# Altium schematic sheet solenoid_drv.SchDoc
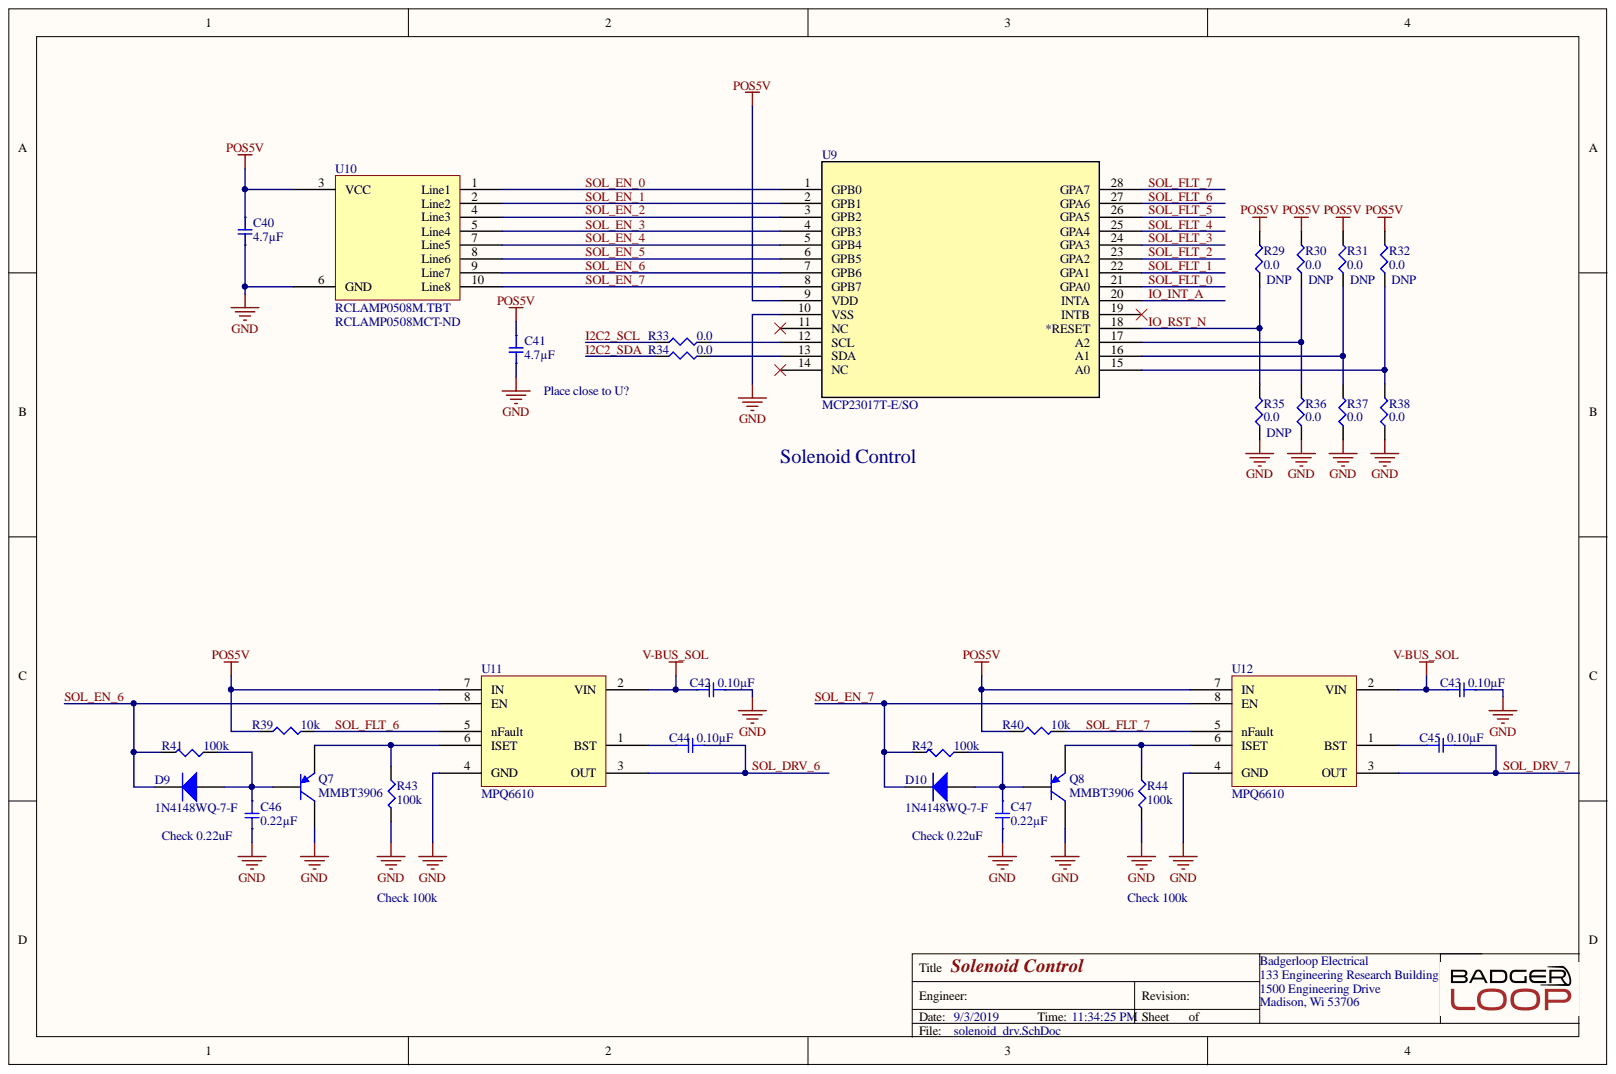
[diagram p0: solenoid_drv.SchDoc]

## Parts
C40  4.7µF  [SMD-0805C]
C41  4.7µF  [SMD-0805C]
C42  0.10µF  [SMD-0805C]
C43  0.10µF  [SMD-0805C]
C44  0.10µF  [SMD-0805C]
C45  0.10µF  [SMD-0805C]
C46  0.22µF  [SMD-0805C]
C47  0.22µF  [SMD-0805C]
D9  1N4148WQ-7-F  [INDM3838X18N]
D10  1N4148WQ-7-F  [INDM3838X18N]
Q7  MMBT3906  [SOTFL103P240X110-3N]
Q8  MMBT3906  [SOTFL103P240X110-3N]
R29  0.0  [SMD-0805-RES]
R30  0.0  [SMD-0805-RES]
R31  0.0  [SMD-0805-RES]
R32  0.0  [SMD-0805-RES]
R33  0.0  [SMD-0805-RES]
R34  0.0  [SMD-0805-RES]
R35  0.0  [SMD-0805-RES]
R36  0.0  [SMD-0805-RES]
R37  0.0  [SMD-0805-RES]
R38  0.0  [SMD-0805-RES]
R39  10k  [SMD-0805-RES]
R40  10k  [SMD-0805-RES]
R41  100k  [SMD-0805-RES]
R42  100k  [SMD-0805-RES]
R43  100k  [SMD-0805-RES]
R44  100k  [SMD-0805-RES]
U9  MCP23017T-E/SO  [SOIC28-W_MC-M]
U10  RCLAMP0508M.TBT  Supplier Part Number 1: RCLAMP0508MCT-ND  [SSOP50P490X175-10N]
U11  MPQ6610  [SOP127P600X175-8N]
U12  MPQ6610  [SOP127P600X175-8N]

## Nets
GND: C40.2 C41.2 C42.2 C43.2 C46.2 C47.2 Q7.3 Q8.3 R35.1 R36.1 R37.1 R38.1 R43.2 R44.2 U9.10(VSS) U10.6(GND) U11.4(GND) U12.4(GND)
POS5V: C40.1 C41.1 R29.2 R30.2 R31.2 R32.2 R39.1 R40.1 U9.9(VDD) U10.3(VCC) U11.7(IN) U12.7(IN)
V-BUS_SOL: C42.1 C43.1 U11.2(VIN) U12.2(VIN)
I2C2_SCL: R33.1
I2C2_SDA: R34.1
IO_INT_A: U9.20(INTA)
IO_RST_N: R29.1 R35.2 U9.18(*RESET)
SOL_DRV_6: C44.2 U11.3(OUT)
SOL_DRV_7: C45.2 U12.3(OUT)
SOL_EN_0: U9.1(GPB0) U10.1(Line1)
SOL_EN_1: U9.2(GPB1) U10.2(Line2)
SOL_EN_2: U9.3(GPB2) U10.4(Line3)
SOL_EN_3: U9.4(GPB3) U10.5(Line4)
SOL_EN_4: U9.5(GPB4) U10.7(Line5)
SOL_EN_5: U9.6(GPB5) U10.8(Line6)
SOL_EN_6: D9.2 R41.2 U9.7(GPB6) U10.9(Line7) U11.8(EN)
SOL_EN_7: D10.2 R42.2 U9.8(GPB7) U10.10(Line8) U12.8(EN)
SOL_FLT_0: U9.21(GPA0)
SOL_FLT_1: U9.22(GPA1)
SOL_FLT_2: U9.23(GPA2)
SOL_FLT_3: U9.24(GPA3)
SOL_FLT_4: U9.25(GPA4)
SOL_FLT_5: U9.26(GPA5)
SOL_FLT_6: R39.2 U9.27(GPA6) U11.5(nFault)
SOL_FLT_7: R40.2 U9.28(GPA7) U12.5(nFault)
NetC44_1: C44.1 U11.1(BST)
NetC45_1: C45.1 U12.1(BST)
NetC46_1: C46.1 D9.1 Q7.2 R41.1
NetC47_1: C47.1 D10.1 Q8.2 R42.1
NetQ7_1: Q7.1 R43.1 U11.6(ISET)
NetQ8_1: Q8.1 R44.1 U12.6(ISET)
NetR30_1: R30.1 R36.2 U9.17(A2)
NetR31_1: R31.1 R37.2 U9.16(A1)
NetR32_1: R32.1 R38.2 U9.15(A0)
NetR33_2: R33.2 U9.12(SCL)
NetR34_2: R34.2 U9.13(SDA)

Not connected: U9 11(NC) 14(NC) 19(INTB)

## Library data of the parts
C40 C41 = GRM21BR60J475KA11L: CAP CER 4.7UF 6.3V X5R 0805; Manufacturer: Murata Electronics North America; @ qty: 0; Minimum Quantity: 1; Tolerance: ±10%; Unit Price (USD): 0.28; Applications: General Purpose; Component Kind: Standard; Datasheets: http://www.murata.com/~/media/webrenewal/support/library/catalog/products/capacitor/mlcc/c02e.pdf; Digi-Key Part Number: 490-9749-1-ND; Factory Stock: 0; Features: -; Height - Seated (Max): -; Image: //media.digikey.com/Renders/Murata%20Renders/0805%20(2012%20Metric)%20.85mm.jpg; Lead Spacing: -; Lead Style: -; Library Name: capacitor.SchLib; Mounting Type: Surface Mount, MLCC; Operating Temperature: -55°C ~ 85°C; Package / Case: 0805 (2012 Metric); Packaging: Cut Tape (CT); Part Number: GRM21BR60J475KA11L; Part Status: Active; Quantity Available: 2821; Ratings: -; Series: GRM; Size / Dimension: 0.079" L x 0.049" W (2.00mm x 1.25mm); Temperature Coefficient: X5R; Thickness (Max): 0.055" (1.40mm); Value: 4.7µF; Voltage: 6.3V
C42-C45 = GRM21B7U1A104JA01L: CAP CER 0.1UF 10V U2J 0805; Manufacturer: Murata Electronics North America; @ qty: 0; Minimum Quantity: 1; Tolerance: ±5%; Unit Price (USD): 0.4; Applications: General Purpose; Component Kind: Standard; Datasheets: http://www.murata.com/~/media/webrenewal/support/library/catalog/products/capacitor/mlcc/c02e.pdf; Digi-Key Part Number: 490-11592-1-ND; Factory Stock: 0; Features: -; Height - Seated (Max): -; Image: //media.digikey.com/Renders/Murata%20Renders/0805%20(2012%20Metric)%20.85mm.jpg; Lead Spacing: -; Lead Style: -; Library Name: capacitors.SchLib; Mounting Type: Surface Mount, MLCC; Operating Temperature: -55°C ~ 125°C; Package / Case: 0805 (2012 Metric); Packaging: Cut Tape (CT); Part Number: GRM21B7U1A104JA01L; Part Status: Active; Quantity Available: 3390; Ratings: -; Series: GRM; Size / Dimension: 0.079" L x 0.049" W (2.00mm x 1.25mm); Temperature Coefficient: U2J; Thickness (Max): 0.053" (1.35mm); Value: 0.10µF; Voltage: 10V
C46 C47 = LLA215R71A224MA14L: CAP CER 0.22UF 10V X7R 0805; Manufacturer: Murata Electronics North America; @ qty: 0; Minimum Quantity: 1; Tolerance: ±20%; Unit Price (USD): 0.36; Applications: Bypass, Decoupling; Component Kind: Standard; Datasheets: http://www.murata.com/~/media/webrenewal/support/library/catalog/products/capacitor/mlcc/c02e.pdf; Digi-Key Part Number: 490-4432-1-ND; Factory Stock: 0; Features: Low ESL (Multi-Terminal); Height - Seated (Max): -; Image: //media.digikey.com/Renders/Murata%20Renders/0805-LLA.jpg; Lead Spacing: -; Lead Style: -; Library Name: capacitors.SchLib; Mounting Type: Surface Mount, MLCC; Operating Temperature: -55°C ~ 125°C; Package / Case: 0805 (2012 Metric); Packaging: Cut Tape (CT); Part Number: LLA215R71A224MA14L; Part Status: Active; Quantity Available: 9914; Ratings: -; Series: LLA; Size / Dimension: 0.079" L x 0.049" W (2.00mm x 1.25mm); Temperature Coefficient: X7R; Thickness (Max): 0.022" (0.55mm); Value: 0.22µF; Voltage: 10V
D9 D10 = 1N4148WQ-7-F: Diodes - General Purpose, Power, Switching SMT Fast Switching Diode; Manufacturer: Diodes Inc.; Component Kind: Standard; Digikey Link: https://www.digikey.com/product-detail/en/diodes-incorporated/1N4148WQ-7-F/1N4148WQ-7-FDITR-ND/8136126; Digikey P/N: 1N4148WQ-7-FDITR-ND; Library Name: misc.SchLib; Manufacturer P/N: 1N4148WQ-7-F
Q7 Q8 = MMBT3906-7-F: Manufacturer: Diodes Zetex; Collector Base Voltage (VCBO): 40V; Collector Emitter Saturation Voltage: -400mV; Continuous Collector Current: -200mA; Element Configuration: Single; Emitter Base Voltage (VEBO): 6V; Frequency: 250MHz; hFE Min: 100; Max Collector Current: 200mA; Max Power Dissipation: 300mW; Number of Elements: 1; Polarity: PNP; Voltage Rating (DC): -40V; Case/Package: SOT-23; Collector Emitter Breakdown Voltage: 40V; Collector Emitter Voltage (VCEO): 40V; Component Kind: Standard; ComponentLink1Description: https://datasheet.ciiva.com/27052/1540746-27052408.pdf?src-supplier=Jameco; ComponentLink1URL: https://datasheet.ciiva.com/27052/1540746-27052408.pdf?src-supplier=Jameco; ComponentLink2Description: https://datasheet.ciiva.com/15139/getdatasheetpartid-255292-15139821.pdf?src-supplier=Verical; ComponentLink2URL: https://datasheet.ciiva.com/15139/getdatasheetpartid-255292-15139821.pdf?src-supplier=Verical; Contact Plating: Tin,Matte; Current Rating: -200mA; Gain Bandwidth Product: 250MHz; Height: 1mm; Lead Free: Lead Free; Length: 3.05mm; Library Name: misc.SchLib; Manufacturer Part Number: MMBT3906-7-F; Max Breakdown Voltage: 40V; Max Frequency: 250MHz; Max Operating Temperature: 150°C; Min Operating Temperature: -55°C; Mount: Surface Mount; Number of Pins: 3; Package Quantity: 3000; Packaging: Tape and Reel; Power Dissipation: 300mW; Pricing 1: 1=0.12000, 10=0.11400, 100=0.06190, 500=0.03806, 1000=0.02596 (USD); Radiation Hardening: No; REACH SVHC: No SVHC; RoHS: Yes; Stock 1: 687580; Supplier 1: Digi-Key; Supplier Part Number 1: MMBT3906-FDICT-ND; Transition Frequency: 250MHz; Weight: 0.000282oz; Width: 1.4mm
R29-R38 = ERJ-6GEY0R00V: RES SMD 0.0 OHM JUMPER 1/8W 0805; Manufacturer: Panasonic Electronic Components; @ qty: 1500; Factory Stock: 0; Minimum Quantity: 1; Power (Watts): 0.125W, 1/8W; Unit Price (USD): 0.00544; Component Kind: Standard; Composition: Thick Film; Datasheets: http://industrial.panasonic.com/www-cgi/jvcr13pz.cgi?E+PZ+3+AOA0001+ERJ6GEY0R00V+7+WW; Digi-Key Part Number: P0.0ACT-ND; Failure Rate: -; Features: Automotive AEC-Q200; Height - Seated (Max): 0.028" (0.70mm); Image: //media.digikey.com/Renders/Panasonic%20Renders/ERJ-0805%20Pkg.jpg; Library Name: resistors.SchLib; Number of Terminations: 2; Operating Temperature: -55°C ~ 155°C; Package / Case: 0805 (2012 Metric); Packaging: Cut Tape (CT); Part Number: ERJ-6GEY0R00V; Part Status: Active; Quantity Available: 1597627; Series: ERJ; Size / Dimension: 0.079" L x 0.049" W (2.00mm x 1.25mm); Supplier Device Package: 0805; Temperature Coefficient: -; Tolerance: Jumper; Value: 0.0
  R29-R32 R35: DNP: DNP
R39 R40 = ERJ-P06J103V: RES SMD 10K OHM 5% 1/2W 0805; Manufacturer: Panasonic Electronic Components; @ qty: 1500; Factory Stock: 0; Minimum Quantity: 1; Power (Watts): 0.5W, 1/2W; Temperature Coefficient: ±200ppm/°C; Unit Price (USD): 0.01839; Component Kind: Standard; Composition: Thick Film; Datasheets: https://industrial.panasonic.com/cdbs/www-data/pdf/RDA0000/AOA0000C243.pdf; Digi-Key Part Number: P10KADCT-ND; Failure Rate: -; Features: Automotive AEC-Q200, Pulse Withstanding; Height - Seated (Max): 0.028" (0.70mm); Image: //media.digikey.com/Renders/Panasonic%20Renders/ERJ-0805%20Pkg.jpg; Library Name: resistors.SchLib; Number of Terminations: 2; Operating Temperature: -55°C ~ 155°C; Package / Case: 0805 (2012 Metric); Packaging: Cut Tape (CT); Part Number: ERJ-P06J103V; Part Status: Active; Quantity Available: 413576; Series: ERJ; Size / Dimension: 0.079" L x 0.049" W (2.00mm x 1.25mm); Supplier Device Package: 0805; Tolerance: ±5%; Value: 10k
R41-R44 = ERJ-P06J104V: RES SMD 100K OHM 5% 1/2W 0805; Manufacturer: Panasonic Electronic Components; @ qty: 1500; Factory Stock: 0; Minimum Quantity: 1; Power (Watts): 0.5W, 1/2W; Temperature Coefficient: ±200ppm/°C; Unit Price (USD): 0.01839; Component Kind: Standard; Composition: Thick Film; Datasheets: https://industrial.panasonic.com/cdbs/www-data/pdf/RDA0000/AOA0000C243.pdf; Digi-Key Part Number: P100KADCT-ND; Failure Rate: -; Features: Automotive AEC-Q200, Pulse Withstanding; Height - Seated (Max): 0.028" (0.70mm); Image: //media.digikey.com/Renders/Panasonic%20Renders/ERJ-0805%20Pkg.jpg; Library Name: resistors.SchLib; Number of Terminations: 2; Operating Temperature: -55°C ~ 155°C; Package / Case: 0805 (2012 Metric); Packaging: Cut Tape (CT); Part Number: ERJ-P06J104V; Part Status: Active; Quantity Available: 82264; Series: ERJ; Size / Dimension: 0.079" L x 0.049" W (2.00mm x 1.25mm); Supplier Device Package: 0805; Tolerance: ±5%; Value: 100k
U9 = MCP23017T-E/SO: IC I/O EXPANDER I2C 16B 28SOIC; Manufacturer: Microchip; Component Kind: Standard; Digikey Link: https://www.digikey.com/product-detail/en/microchip-technology/MCP23017T-E-SO/MCP23017T-E-SOCT-ND/5358289; Digikey P/N: MCP23017T-E/SOCT-ND; Library Name: ic.SchLib; Manufacturer P/N: MCP23017T-E/SO
U10 = RCLAMP0508M.TBT: TVS DIODE 5VWM 25VC 10MSOP; Manufacturer: Semtech; Breakdown Voltage: 6V; Capacitance: 3pF; Clamping Voltage: 25V; Direction: Unidirectional; Leakage Current: 5uA; Max Power Dissipation: 300W; Number of Bidirectional Channels: 8; Number of Elements: 1; Operating Supply Voltage: 5V; Peak Pulse Current: 12A; Power Line Protection: Yes; Test Current: 1mA; Case/Package: MSOP; Component Kind: Standard; ComponentLink1Description: https://datasheet.ciiva.com/339/rclamp0508m-339445.pdf?src-supplier=Digi-Key; ComponentLink1URL: https://datasheet.ciiva.com/339/rclamp0508m-339445.pdf?src-supplier=Digi-Key; ComponentLink2Description: https://datasheet.ciiva.com/339/rclamp0508m-339446.pdf?src-supplier=Digi-Key; ComponentLink2URL: https://datasheet.ciiva.com/339/rclamp0508m-339446.pdf?src-supplier=Digi-Key; ComponentLink3Description: https://datasheet.ciiva.com/8029/rclamp0508m-8029926.pdf?src-supplier=Element14; ComponentLink3URL: https://datasheet.ciiva.com/8029/rclamp0508m-8029926.pdf?src-supplier=Element14; ComponentLink4Description: https://datasheet.ciiva.com/339/rclamp0508m-339447.pdf?src-supplier=Digi-Key; ComponentLink4URL: https://datasheet.ciiva.com/339/rclamp0508m-339447.pdf?src-supplier=Digi-Key; ComponentLink5Description: https://datasheet.ciiva.com/16294/rclamp0508m-16294599.pdf?src-supplier=Future+Electronics; ComponentLink5URL: https://datasheet.ciiva.com/16294/rclamp0508m-16294599.pdf?src-supplier=Future+Electronics; ComponentLink6Description: https://datasheet.ciiva.com/5748/rclamp0508m-5748392.pdf?src-supplier=Farnell; ComponentLink6URL: https://datasheet.ciiva.com/5748/rclamp0508m-5748392.pdf?src-supplier=Farnell; ComponentLink7Description: https://datasheet.ciiva.com/11193/rclamp0508m-11193708.pdf?src-supplier=Avnet; ComponentLink7URL: https://datasheet.ciiva.com/11193/rclamp0508m-11193708.pdf?src-supplier=Avnet; ComponentLink8Description: https://datasheet.ciiva.com/25957/rclamp0508m-25957549.pdf?src-supplier=Avnet+Europe; ComponentLink8URL: https://datasheet.ciiva.com/25957/rclamp0508m-25957549.pdf?src-supplier=Avnet+Europe; Depth: 3.1mm; Lead Free: Lead Free; Length: 3.1mm; Library Name: ic.SchLib; Manufacturer Part Number: RCLAMP0508M.TBT; Max Breakdown Voltage: 6V; Max Operating Temperature: 125°C; Min Breakdown Voltage: 6V; Min Operating Temperature: -55°C; Mount: Surface Mount; Number of Channels: 8; Number of Pins: 10; Packaging: Tape and Reel; Peak Pulse Power: 300W; Polarity: Unidirectional; Pricing 1: 1=2.89000, 10=2.59300, 25=2.44640, 100=2.08420, 250=1.95700 (USD); Radiation Hardening: No; REACH SVHC: No SVHC; Reverse Breakdown Voltage: 6V; Reverse Standoff Voltage: 5V; RoHS: Yes; Stock 1: 4791; Supplier 1: Digi-Key; Termination: SMD/SMT; Voltage Rating (DC): 5V; Width: 3mm; Working Voltage: 5V
U11 U12 = MPQ6610: Component Kind: Standard; Library Name: ic.SchLib
